# Altium schematic sheet Sheet3.SchDoc
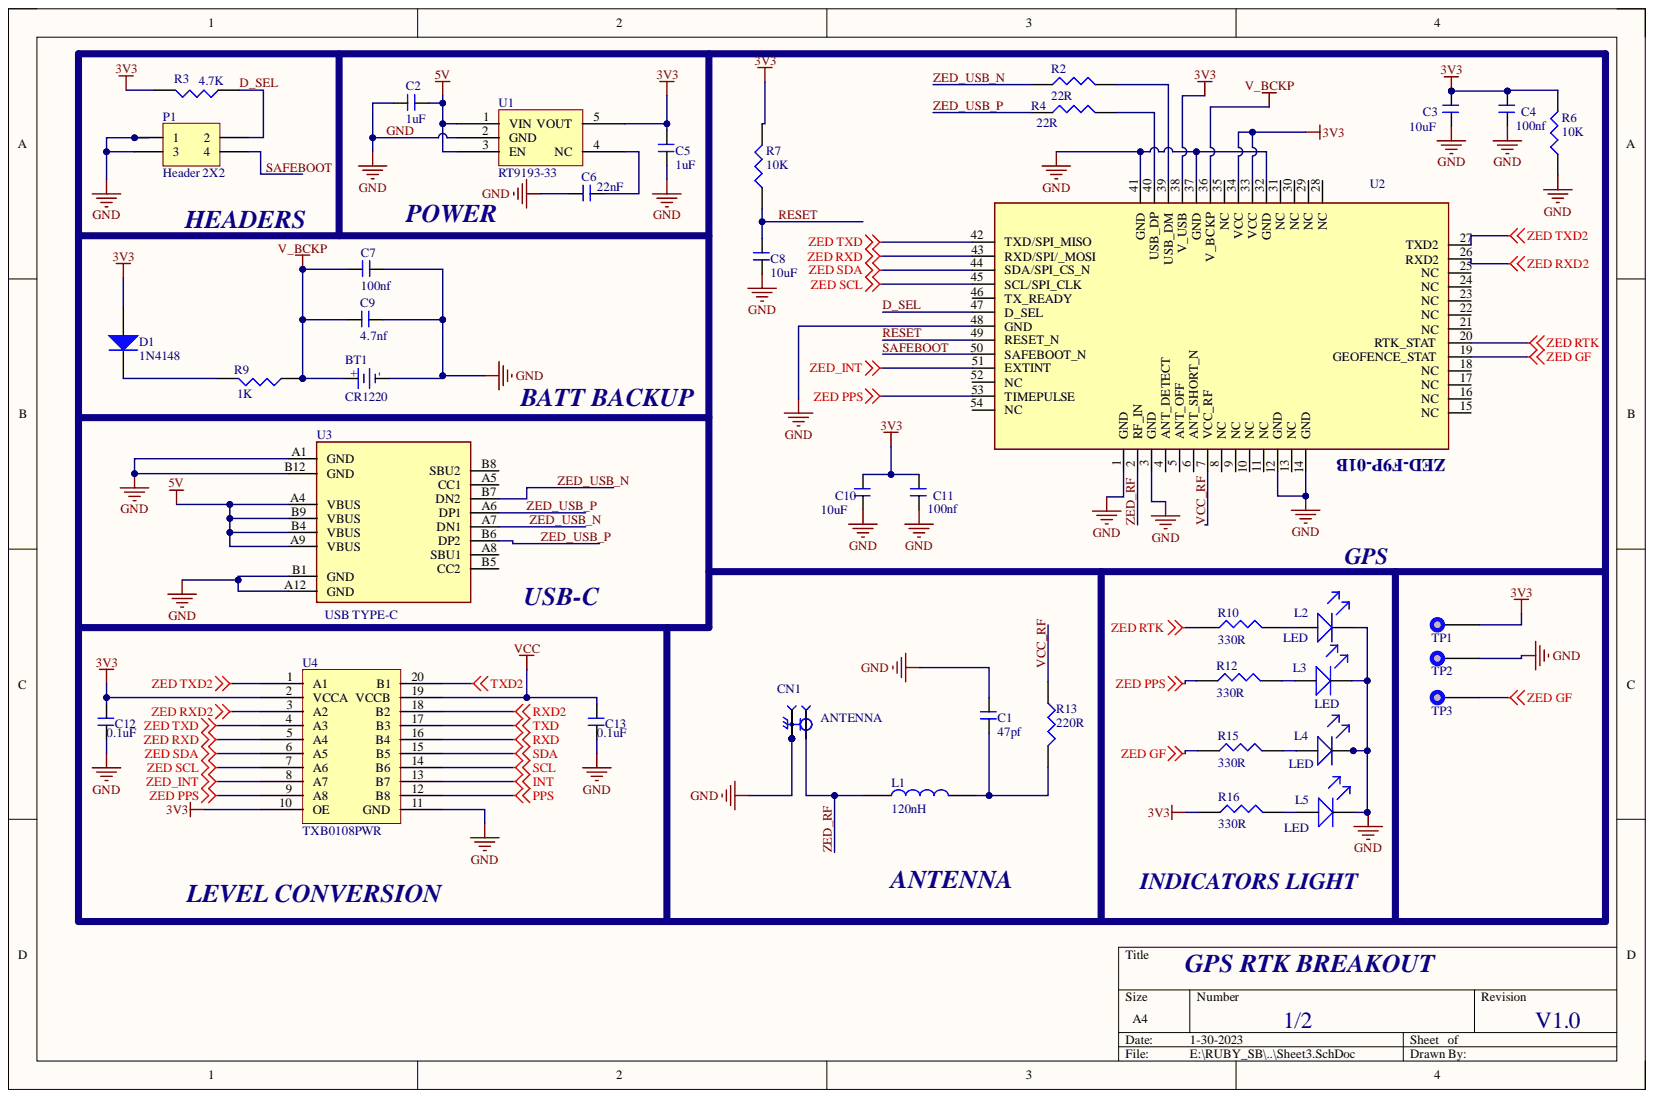
[diagram p0: Sheet3.SchDoc]

## Parts
BT1  CR1220  [Coin Cell Holder-1220]
C1  47pf  [CAP_0603_NONPOLAR]
C2  1uF  [CAP_0603_NONPOLAR]
C3  10uF  [CAP_0603_NONPOLAR]
C4  100nf  [CAP_0603_NONPOLAR]
C5  1uF  [CAP_0603_NONPOLAR]
C6  22nF  [CAP_0603_NONPOLAR]
C7  100nf  [CAP_0603_NONPOLAR]
C8  10uF  [CAP_0603_NONPOLAR]
C9  4.7nf  [CAP_0603_NONPOLAR]
C10  10uF  [CAP_0603_NONPOLAR]
C11  100nf  [CAP_0603_NONPOLAR]
C12  0.1uF  [C_0603]
C13  0.1uF  [C_0603]
CN1  ANTENNA  [SAMTEC_SMA-J-P-X-RA-TH1]
D1  1N4148  [SOD-80]
L1  120nH  [0603]
L2  LED  [LED_0603_neg]
L3  LED  [LED_0603_neg]
L4  LED  [LED_0603_neg]
L5  LED  [LED_0603_neg]
P1  Header 2X2  [2X2P]
R2  22R  [0603]
R3  4.7K  [0603]
R4  22R  [0603]
R6  10K  [0603]
R7  10K  [0603]
R9  1K  [0603]
R10  330R  [0603]
R12  330R  [0603]
R13  220R  [0603]
R15  330R  [0603]
R16  330R  [0603]
TP1  TESTPOINT  [TESTPOINT]  (value not drawn)
TP2  TESTPOINT  [TESTPOINT]  (value not drawn)
TP3  TESTPOINT  [TESTPOINT]  (value not drawn)
U1  RT9193-33  [SOT-23-5]
U2  ZED-F9P-01B  [ZED-F9P-01B_LGA PACKAGE]
U3  USB TYPE-C  [USB-TYPE-C (C165948)]
U4  TXB0108PWR  [TSSOP 20P]

## Nets
3V3: C3.1 C4.1 C5.1 C10.1 C11.1 C12.1 D1.A R3.2 R6.2 R7.2 R16.1 TP1.1 U1.5(VOUT) U2.33(VCC) U2.34(VCC) U2.38(V_USB) U4.2(VCCA) U4.10(OE)
5V: C2.1 U1.1(VIN) U1.3(EN) U3.A4(VBUS) U3.A9(VBUS) U3.B4(VBUS) U3.B9(VBUS)
INT: U4.13(B7)
PPS: U4.12(B8)
RXD: U4.16(B4)
RXD2: U4.18(B2)
SCL: U4.14(B6)
SDA: U4.15(B5)
TXD: U4.17(B3)
TXD2: U4.20(B1)
V_BCKP: BT1.A C7.2 C9.2 R9.1 U2.36(V_BCKP)
VCC: C13.1 U4.19(VCCB)
ZED GF: R15.1 TP3.1 U2.19(GEOFENCE_STAT)
ZED PPS: R12.1 U2.53(TIMEPULSE) U4.9(A8)
ZED RTK: R10.1 U2.20(RTK_STAT)
ZED RXD: U2.43(RXD/SPI/_MOSI) U4.5(A4)
ZED RXD2: U2.26(RXD2) U4.3(A2)
ZED SCL: U2.45(SCL/SPI_CLK) U4.7(A6)
ZED SDA: U2.44(SDA/SPI_CS_N) U4.6(A5)
ZED TXD: U2.42(TXD/SPI_MISO) U4.4(A3)
ZED TXD2: U2.27(TXD2) U4.1(A1)
ZED_INT: U2.51(EXTINT) U4.8(A7)
D_SEL: P1.2 R3.1 U2.47(D_SEL)
GND: BT1.K C1.1 C2.2 C3.2 C4.2 C5.2 C6.2 C7.1 C8.2 C9.1 C10.2 C11.2 C12.2 C13.2 CN1.2 CN1.3 CN1.4 CN1.5 L2.K L3.K L4.K L5.K P1.1 P1.3 R6.1 TP2.1 U1.2(GND) U2.1(GND) U2.3(GND) U2.12(GND) U2.14(GND) U2.32(GND) U2.37(GND) U2.41(GND) U2.48(GND) U3.A1(GND) U3.A12(GND) U3.B1(GND) U3.B12(GND) U4.11(GND)
RESET: C8.1 R7.1 U2.49(RESET_N)
SAFEBOOT: P1.4 U2.50(SAFEBOOT_N)
VCC_RF: R13.1 U2.7(VCC_RF)
ZED_RF: CN1.1 L1.1 U2.2(RF_IN)
ZED_USB_N: R2.1 U3.A7(DN1) U3.B7(DN2)
ZED_USB_P: R4.1 U3.A6(DP1) U3.B6(DP2)
NetC1_2: C1.2 L1.2 R13.2
NetC6_1: C6.1 U1.4(NC)
NetD1_K: D1.K R9.2
NetL2_A: L2.A R10.2
NetL3_A: L3.A R12.2
NetL4_A: L4.A R15.2
NetL5_A: L5.A R16.2
NetR2_2: R2.2 U2.39(USB_DM)
NetR4_2: R4.2 U2.40(USB_DP)

Not connected: U2 4(ANT_DETECT) 5(ANT_OFF) 6(ANT_SHORT_N) 8(NC) 9(NC) 10(NC) 11(NC) 13(NC) 15(NC) 16(NC) 17(NC) 18(NC) 21(NC) 22(NC) 23(NC) 24(NC) 25(NC) 28(NC) 29(NC) 30(NC) 31(NC) 35(NC) 46(TX_READY) 52(NC) 54(NC); U3 A5(CC1) A8(SBU1) B5(CC2) B8(SBU2)

## Library data of the parts
BT1 = BATTERY: Component Kind: Standard; Library Name: dxp_pasl_lib.IntLib
C1-C13 = CAP_NONPOLAR: Component Kind: Standard; Library Name: dxp_pasl_lib.IntLib
CN1 = SMA-J-P-X-RA-TH1: SMA Connector Jack, Female Socket 50Ohm Through Hole, Right Angle Solder; MF: Samtec; MP: SMA-J-P-H-RA-TH1; Component Kind: Standard; Digi-Key_Part_Number: SAM8856-ND; Library Name: SMA-J-P-X-RA-TH1.IntLib; Package: None; SnapEDA_Link: https://www.snapeda.com/parts/SMA-J-P-H-RA-TH1/Samtec%20Inc./view-part/134498/?ref=snap; Toby_UK-Purchase-URL: https://snapeda.com/shop?store=Toby+UK&id=134498
D1 = Diode: Default Diode; Code_JEDEC: DO-214-AB; Component Kind: Standard; LatestRevisionDate: 17-Jul-2002; LatestRevisionNote: Re-released for DXP Platform.; Library Name: ALTIUM2 NEW-LIB.IntLib; PackageDocument: Sep-1996; PackageReference: SMC; Published: 8-Jun-2000; Publisher: Altium Limited
L1 = INDUCTOR1: Component Kind: Standard; Library Name: ALTIUM2 NEW-LIB.IntLib
P1 = Header 2X2: Header, 2-Pin, Dual row; Component Kind: Standard; LatestRevisionDate: 17-Jul-2002; LatestRevisionNote: Re-released for DXP Platform.; Library Name: Miscellaneous Connectors.IntLib; Signal Integrity: Connector; Publisher: Altium Limited
R2-R4 R6 R7 R9 R10 R12 R13 R15 R16 = RES: Component Kind: Standard; Library Name: dxp_pasl_lib.IntLib
U1 = AP2112: 600mA CMOS LDO REGULATOR WITH ENABLE SOT-23-5 PACKAGE; Component Kind: Standard; Library Name: dxp_pasl_lib.IntLib
U2 = IC_ZED-F9P-01B: Component Kind: Standard; Library Name: manju_lib.SchLib
U3 = USB TYPE-C _C165948: Component Kind: Standard; Library Name: manju_lib.SchLib
U4 = TXB0108EPWR 2: Level Translators, Shifters RoHS TSSOP-20 # C53406; Component Kind: Standard; Library Name: dxp_pasl_lib.IntLib
L2-L5 = LED: Component Kind: Standard; Library Name: dxp_pasl_lib.IntLib
TP1-TP3 = TESTPOINT: Component Kind: Standard; Library Name: dxp_pasl_lib.IntLib
